# Altium schematic sheet DC_DRIVERS.SchDoc
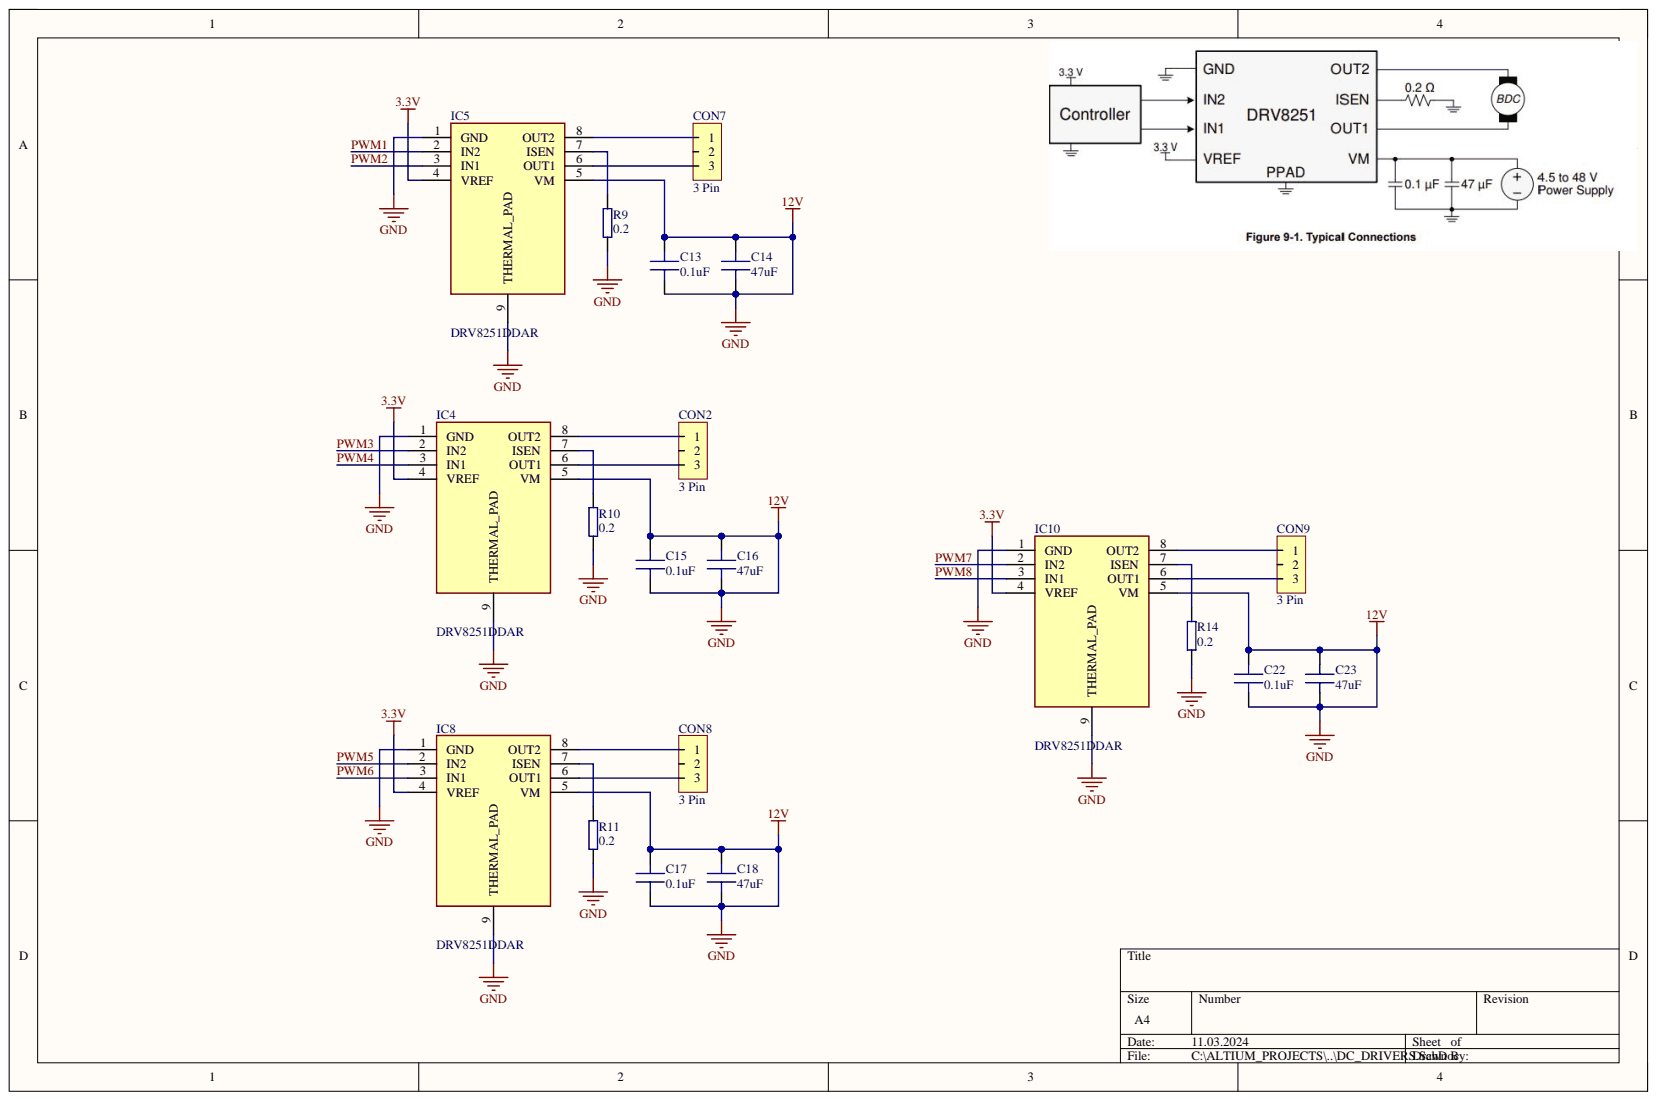
[diagram p0: DC_DRIVERS.SchDoc]

## Parts
C13  0.1uF  [C 0603]
C14  47uF  [C 0805]
C15  0.1uF  [C 0603]
C16  47uF  [C 0805]
C17  0.1uF  [C 0603]
C18  47uF  [C 0805]
C22  0.1uF  [C 0603]
C23  47uF  [C 0805]
CON2  3 Pin  [BM03B-SRSS-TB]
CON7  3 Pin  [BM03B-SRSS-TB]
CON8  3 Pin  [BM03B-SRSS-TB]
CON9  3 Pin  [BM03B-SRSS-TB]
IC4  DRV8251DDAR  [SOIC127P600X170-9N]
IC5  DRV8251DDAR  [SOIC127P600X170-9N]
IC8  DRV8251DDAR  [SOIC127P600X170-9N]
IC10  DRV8251DDAR  [SOIC127P600X170-9N]
R9  0.2  [R 1206]
R10  0.2  [R 1206]
R11  0.2  [R 1206]
R14  0.2  [R 1206]

## Nets
3.3V: IC4.4(VREF) IC5.4(VREF) IC8.4(VREF) IC10.4(VREF)
12V: C13.1 C13.2 C14.1 C14.2 C15.1 C15.2 C16.1 C16.2 C17.1 C17.2 C18.1 C18.2 C22.1 C22.2 C23.1 C23.2 IC4.1(GND) IC4.5(VM) IC4.9(THERMAL_PAD) IC5.1(GND) IC5.5(VM) IC5.9(THERMAL_PAD) IC8.1(GND) IC8.5(VM) IC8.9(THERMAL_PAD) IC10.1(GND) IC10.5(VM) IC10.9(THERMAL_PAD) R9.2 R10.2 R11.2 R14.2
PWM1: IC5.2(IN2)
PWM2: IC5.3(IN1)
PWM3: IC4.2(IN2)
PWM4: IC4.3(IN1)
PWM5: IC8.2(IN2)
PWM6: IC8.3(IN1)
PWM7: IC10.2(IN2)
PWM8: IC10.3(IN1)
NetCON2_1: CON2.1 IC4.8(OUT2)
NetCON2_3: CON2.3 IC4.6(OUT1)
NetCON7_1: CON7.1 IC5.8(OUT2)
NetCON7_3: CON7.3 IC5.6(OUT1)
NetCON8_1: CON8.1 IC8.8(OUT2)
NetCON8_3: CON8.3 IC8.6(OUT1)
NetCON9_1: CON9.1 IC10.8(OUT2)
NetCON9_3: CON9.3 IC10.6(OUT1)
NetIC4_7: IC4.7(ISEN) R10.1
NetIC5_7: IC5.7(ISEN) R9.1
NetIC8_7: IC8.7(ISEN) R11.1
NetIC10_7: IC10.7(ISEN) R14.1

Not connected: CON2 2; CON7 2; CON8 2; CON9 2

## Library data of the parts
C13-C18 C22 C23 = Capacitor: MLCC Capacitor; Component Kind: Standard; Library Name: Components.IntLib
IC4 IC5 IC8 IC10 = DRV8251DDAR: Integrated Circuit; Manufacturer_Name: Texas Instruments; Arrow Part Number: DRV8251DDAR; Arrow Price/Stock: https://www.arrow.com/en/products/drv8251ddar/texas-instruments?region=nac; Component Kind: Standard; Datasheet Link: https://www.arrow.com/en/products/drv8251ddar/texas-instruments?region=nac; Height: 1.7mm; Manufacturer_Part_Number: DRV8251DDAR; Mouser Part Number: 595-DRV8251DDAR; Mouser Price/Stock: https://www.mouser.co.uk/ProductDetail/Texas-Instruments/DRV8251DDAR?qs=doiCPypUmgHTzZyfapGahQ%3D%3D
R9-R11 R14 = Resistor: General pourpouse resistor; Component Kind: Standard; Library Name: Components.IntLib
CON2 CON7-CON9 = 3 Pin: Component Kind: Standard; Library Name: Connectors.IntLib
